# Altium schematic sheet Programmer.SchDoc
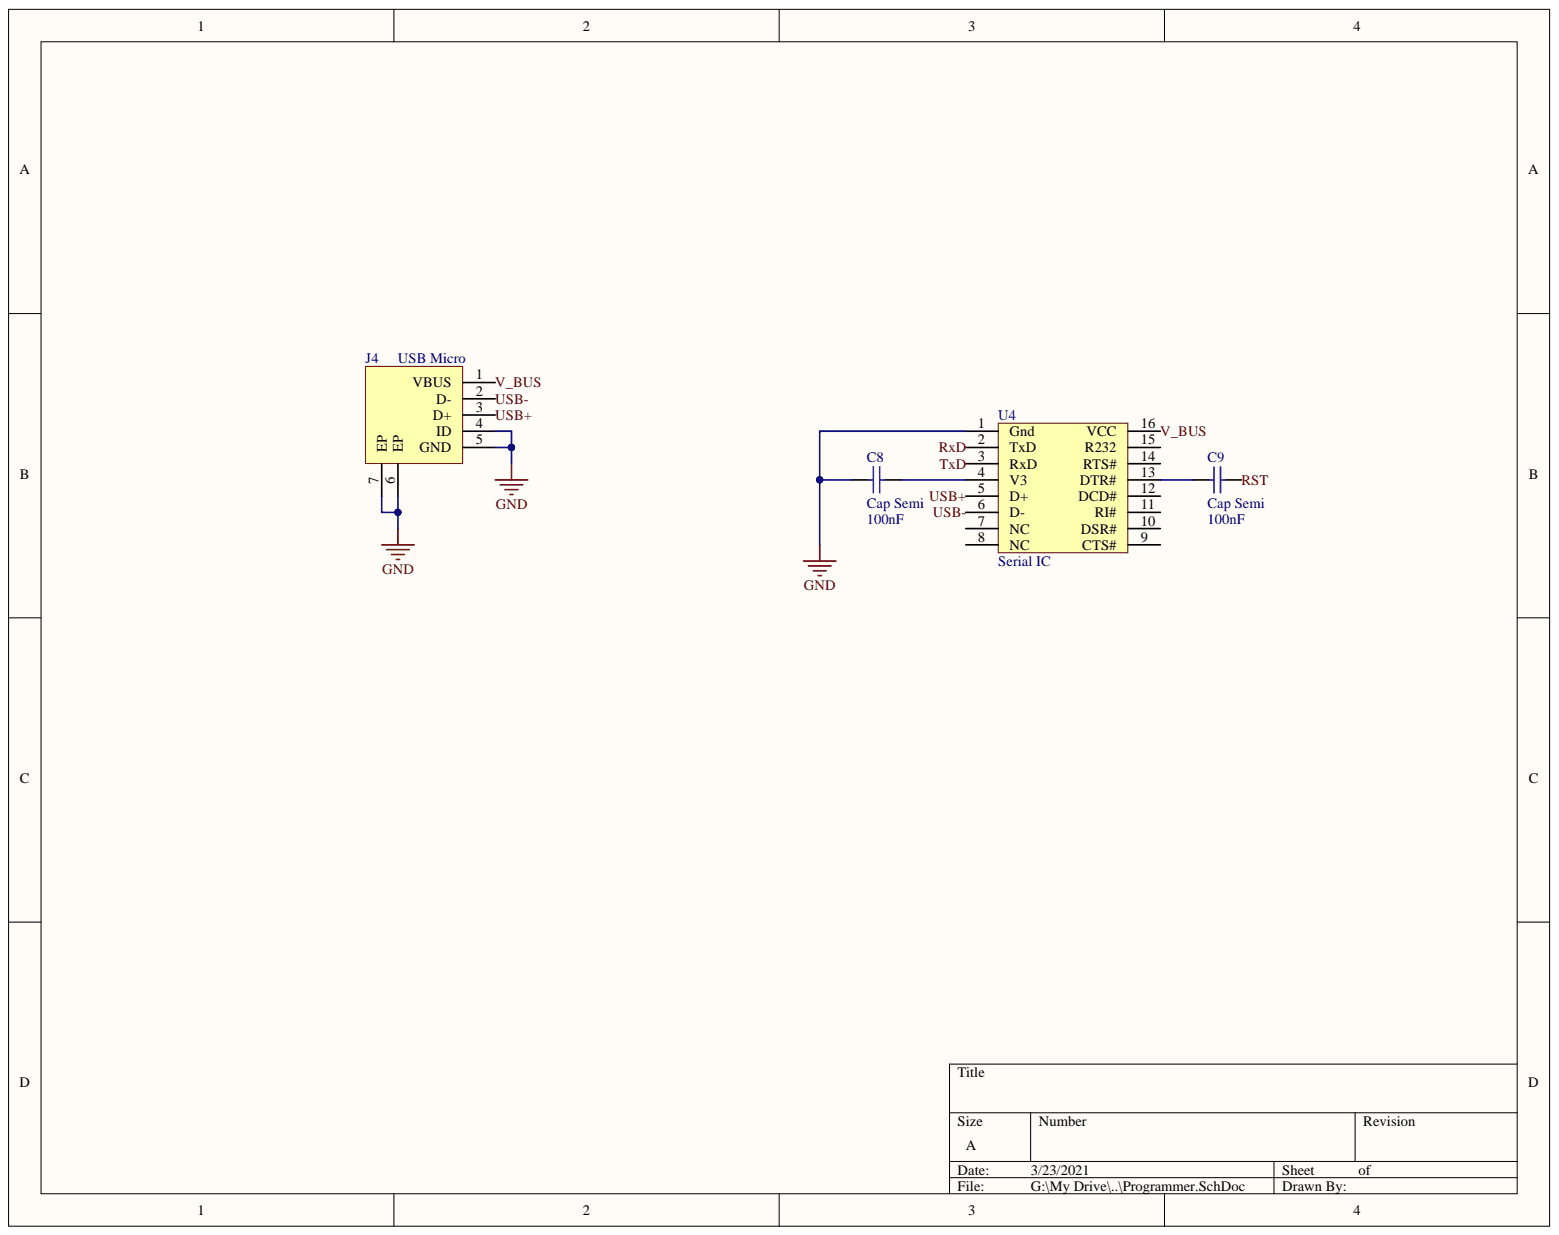
[diagram p0: Programmer.SchDoc]

## Parts
C8  Cap Semi  value 100nF  [1608[0603]]
C9  Cap Semi  value 100nF  [1608[0603]]
J4  USB Micro  [U-D-M5DD-W-1]
U4  Serial IC  [CH340C]

## Nets
GND: C8.2 J4.4(ID) J4.5(GND) J4.6(EP) J4.7(EP) U4.1(Gnd)
RST: C9.1
RxD: U4.2(TxD)
TxD: U4.3(RxD)
USB+: J4.3(D+) U4.5(D+)
USB-: J4.2(D-) U4.6(D-)
V_BUS: J4.1(VBUS) U4.16(VCC)
NetC8_1: C8.1 U4.4(V3)
NetC9_2: C9.2 U4.13(DTR#)

Not connected: U4 7(NC) 8(NC) 9(CTS#) 10(DSR#) 11(RI#) 12(DCD#) 14(RTS#) 15(R232)

## Library data of the parts
C8 C9 = Cap Semi: Capacitor (Semiconductor SIM Model); Component Kind: Standard; LatestRevisionDate: 29-May-2009; LatestRevisionNote: IPC-7351 Footprint Added.; Library Name: Miscellaneous Devices.IntLib; PackageDescription: Chip Capacitor; PackageReference: C1206; Published: 8-Jun-2000; Signal Integrity: Cap Semi; Simulation: CAP; Publisher: Altium Limited
J4 = U-D-M5DD-W-1: USB - Micro B Female USB 2 5 7.4*5.05mm USB Connectors RoHS; Component Kind: Standard; Library Name: U-D-M5DD-W-1.IntLib
U4 = CH340C: Transceiver USB 2.0 2Mbps SOP-16_150mil USB ICs RoHS; Component Kind: Standard; Library Name: CH340C.IntLib
